# Altium schematic sheet RTC.SchDoc(RTC)
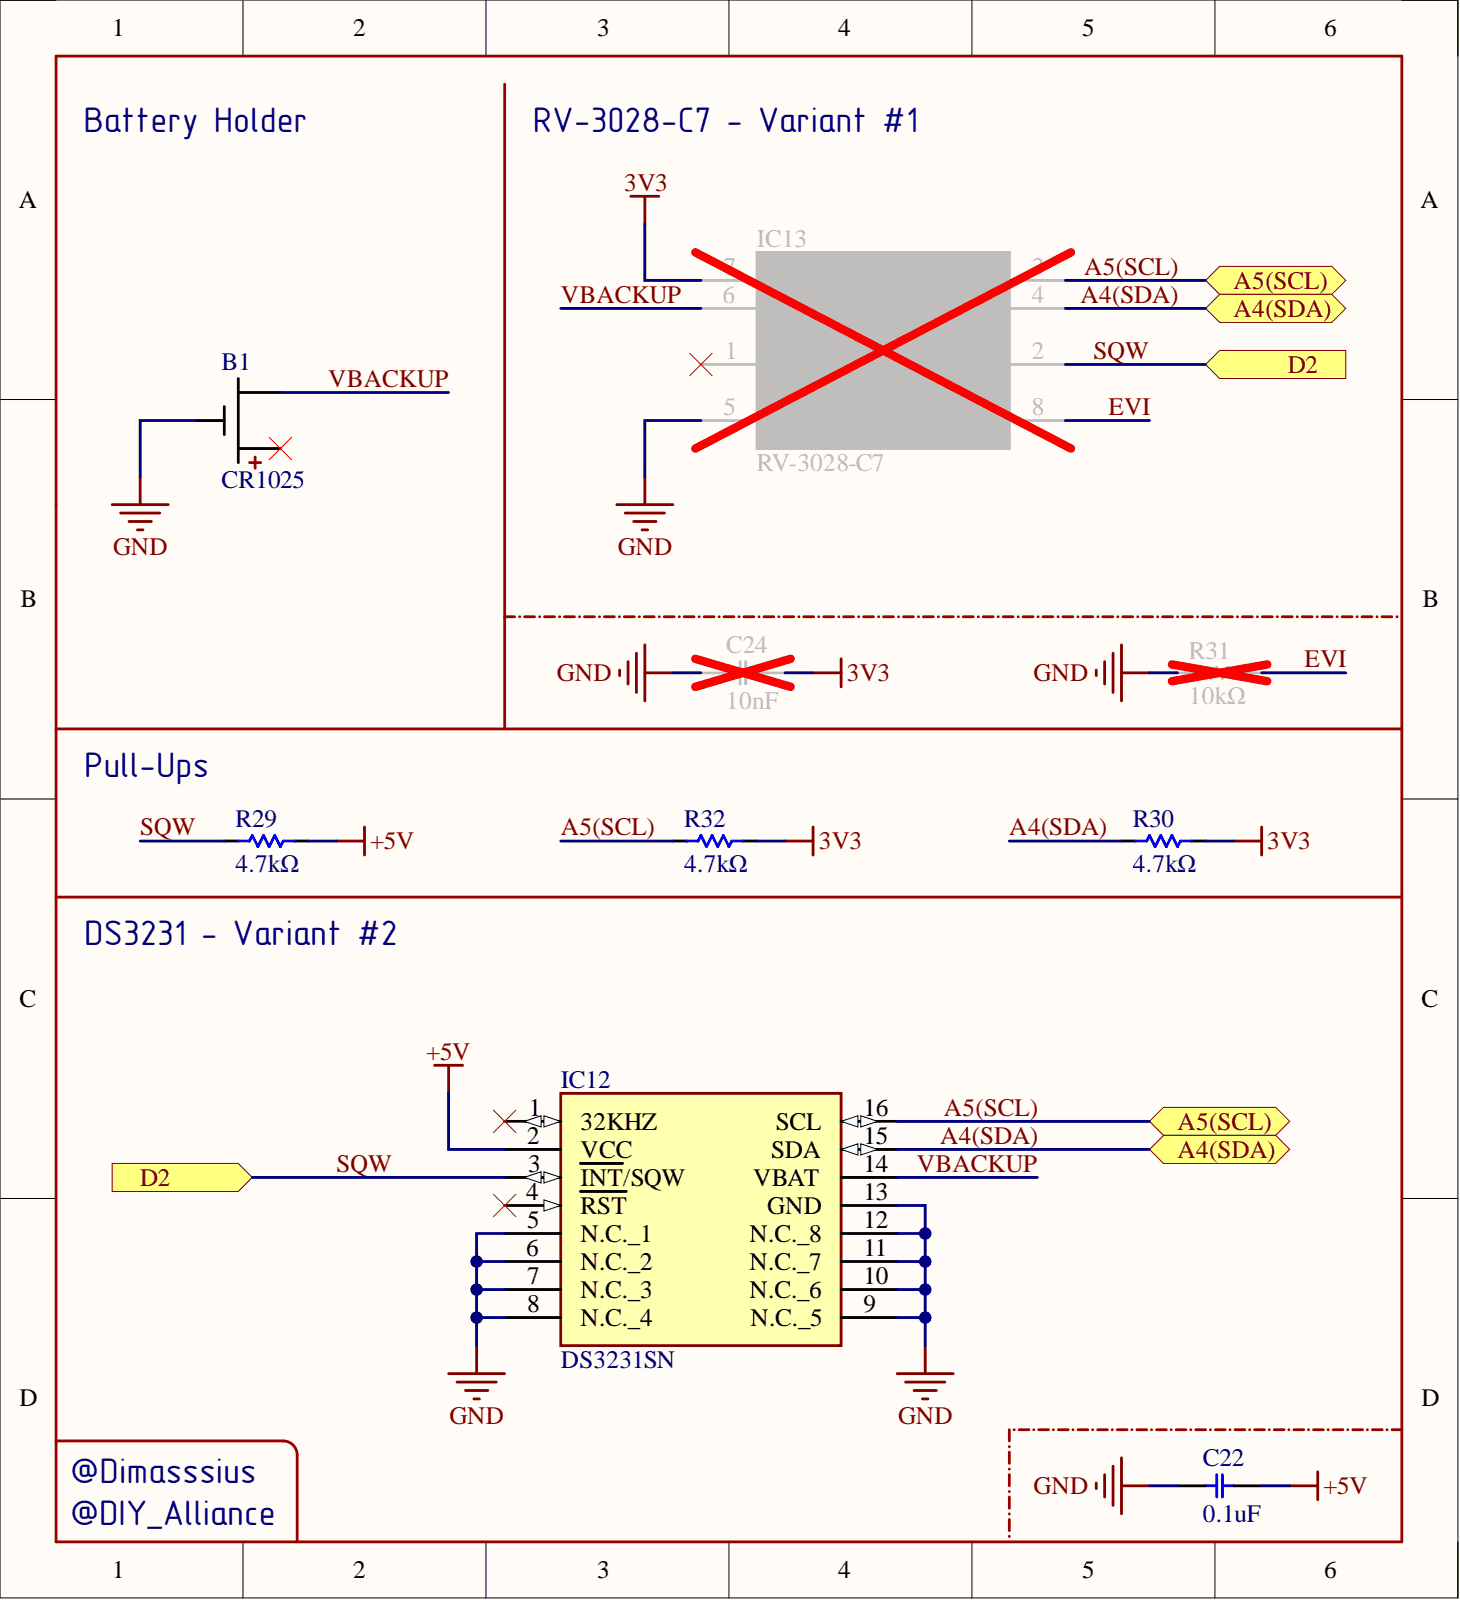
[diagram p0: RTC.SchDoc]

## Parts
B1  CR1025  [CR1025]
C22  0.1uF  [CAP_SMD_MLCC_C0603_(M1608)_C0402A_(M1005A)]
C24  10nF  [CAP_SMD_MLCC_C0603_(M1608)_C0402A_(M1005A)]
IC12  DS3231SN  [SOIC127P1032X265-16N]
IC13  RV-3028-C7  [SMD3215-8P]
R29  4.7kΩ  [RES_SMD_R0603_(M1608)_R0402A_(M1005A)]
R30  4.7kΩ  [RES_SMD_R0603_(M1608)_R0402A_(M1005A)]
R31  10kΩ  [RES_SMD_R0603_(M1608)_R0402A_(M1005A)]
R32  4.7kΩ  [RES_SMD_R0603_(M1608)_R0402A_(M1005A)]

## Ports
A4(SDA), A5(SCL), D2

## Nets
3V3: C24.2 IC13.7(VDD) R30.1 R32.2
+5V: C22.1 IC12.2(VCC) R29.2
GND: B1.1 C22.2 C24.1 IC12.5(N.C._1) IC12.6(N.C._2) IC12.7(N.C._3) IC12.8(N.C._4) IC12.9(N.C._5) IC12.10(N.C._6) IC12.11(N.C._7) IC12.12(N.C._8) IC12.13(GND) IC13.5(GND) R31.2
A4(SDA): IC12.15(SDA) IC13.4(SDA) R30.2 ; port A4(SDA)
A5(SCL): IC12.16(SCL) IC13.3(SCL) R32.1 ; port A5(SCL)
EVI: IC13.8(EVI) R31.1
SQW: IC12.3(INT/SQW) IC13.2(INT) R29.1 ; port D2
VBACKUP: B1.3 IC12.14(VBAT) IC13.6(VBACKUP)

Not connected: B1 2; IC12 1(32KHZ) 4(RST); IC13 1(CLKOUT)

## Library data of the parts
B1 = CR1025: Battery Clip Coin, SMD, CR1025, Cell; Component Kind: Standard; Library Name: SamacSys.SchLib; Value: CR1025
C22 = CAP_SMD_MLCC_0.1UF_6.3V: Capacitor, Ceramic (MLCC), SMD, 100nF, 6.3V, ±10%, X7R, Multilayer Ceramic Capacitors MLCC - SMD/SMT, ROHS; Manufacturer: Murata Electronics North America; Part Number: LLM315R71C104MA11L; @ qty: 0; Minimum Quantity: 1; Tolerance: ±20%; Unit Price (USD): 0.9; Voltage: 16V; Applications: Bypass, Decoupling; Component Kind: Standard; Datasheets: http://www.murata.com/~/media/webrenewal/support/library/catalog/products/capacitor/mlcc/c02e.pdf; Digi-Key Part Number: 490-4495-1-ND; Factory Stock: 0; Features: Low ESL (Multi-Terminal); Height - Seated (Max): -; Image: //media.digikey.com/Renders/Murata%20Renders/1206(LLM315).jpg; Lead Spacing: -; Lead Style: -; Library Name: Capacitors.SchLib; Mounting Type: Surface Mount, MLCC; Operating Temperature: -55°C ~ 125°C; Package / Case: -; Packaging: Cut Tape (CT); Part Status: Active; Quantity Available: 2915; Ratings: -; Series: LLM; Size / Dimension: -; Temperature Coefficient: X7R; Thickness (Max): -; Value: 0.1uF
C24 = CAP_SMD_MLCC_10NF_50V: Capacitor, Ceramic (MLCC), SMD, 10nF, 50V, ±10%, X7R, Multilayer Ceramic Capacitors MLCC - SMD/SMT, ROHS; Manufacturer: Murata Electronics North America; Part Number: GRM31CR60J106KA01L; @ qty: 0; Minimum Quantity: 1; Temperature Coefficient: X5R; Unit Price (USD): 0.24; Voltage: 6.3V; Applications: General Purpose; Component Kind: Standard; Datasheets: http://www.murata.com/~/media/webrenewal/support/library/catalog/products/capacitor/mlcc/c02e.pdf; Digi-Key Part Number: 490-12610-1-ND; Factory Stock: 0; Features: -; Height - Seated (Max): -; Image: //media.digikey.com/Renders/Murata%20Renders/GR331BD72E473KW01L.jpg; Lead Spacing: -; Lead Style: -; Library Name: Capacitors.SchLib; Mounting Type: Surface Mount, MLCC; Operating Temperature: -55°C ~ 85°C; Package / Case: -; Packaging: Cut Tape (CT); Part Status: Active; Quantity Available: 12001; Ratings: -; Series: GRM; Size / Dimension: -; Thickness (Max): -; Tolerance: ±10%; Value: 10nF
IC12 = DS3231SN: Real Time Clock, SOIC-16-300mil, I2C, Real Time Clocks, ROHS; Component Kind: Standard; Datasheet Link: https://datasheet.datasheetarchive.com/originals/distributors/Datasheets-8/DSA-148585.pdf; Height: 2.65mm; Library Name: SamacSys.SchLib; Value: DS3231SN
IC13 = RV-3028-C7: Real Time Clock, SMD3215-8P, I2C, Real Time Clocks, ROHS; Manufacturer_Name: Micro Crystal AG; Component Kind: Standard; Datasheet Link: https://www.microcrystal.com/fileadmin/Media/Products/RTC/Datasheet/RV-3028-C7.pdf; Height: 0.8mm; Library Name: SamacSys.SchLib; Manufacturer_Part_Number: RV-3028-C7; Value: RV-3028-C7
R29 R30 R32 = RES_SMD_4.7KΩ: Resistor, Thick Film Resistor, SMD, 4.7kΩ, ±5%, Chip Resistor - Surface Mount, ROHS; Component Kind: Standard; Library Name: Resistors.SchLib; Value: 4.7kΩ
R31 = RES_SMD_10KΩ: Resistor, Thick Film Resistor, SMD, 10kΩ, ±5%, Chip Resistor - Surface Mount, ROHS; Component Kind: Standard; Library Name: Resistors.SchLib; Value: 10kΩ
